# Altium schematic sheet DHT22.SchDoc(DHT22)
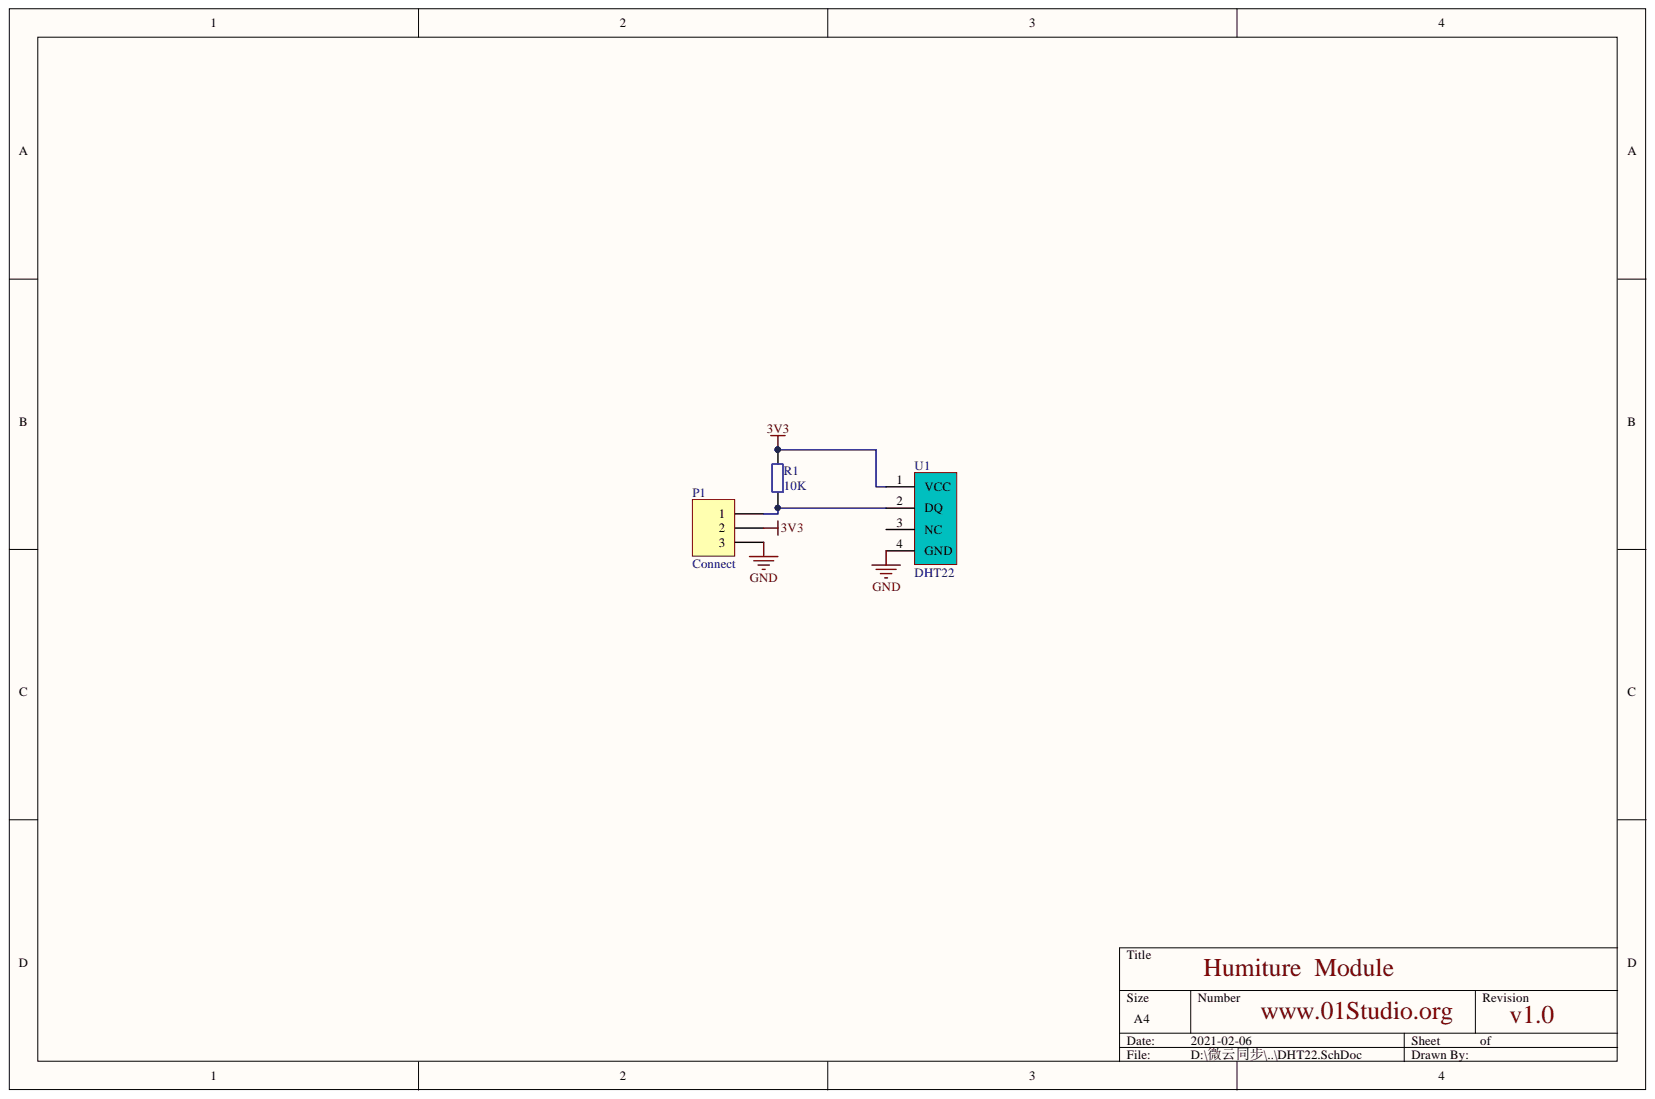
[diagram p0: DHT22.SchDoc]

## Parts
P1  Connect  [XH2.54-3P/卧贴]
R1  value 10K  [R0603]
U1  DHT22  [DHT22]

## Nets
3V3: P1.2 R1.2 U1.1(VCC)
GND: P1.3 U1.4(GND)
NetP1_1: P1.1 R1.1 U1.2(DQ)

Not connected: U1 3(NC)

## Library data of the parts
P1 = Header 3: Header, 3-Pin; Signal Integrity: Connector; Library Name: Miscellaneous Connectors.IntLib; LatestRevisionNote: Re-released for DXP Platform.; LatestRevisionDate: 17-Jul-2002; Component Kind: Standard; Publisher: Altium Limited
R1 = Res2: Resistor; Simulation: RESISTOR; Signal Integrity: Res; Published: 8-Jun-2000; PackageReference: AXIAL-0.4; Library Name: Miscellaneous Devices.IntLib; LatestRevisionNote: Re-released for DXP Platform.; LatestRevisionDate: 17-Jul-2002; Component Kind: Standard; Publisher: Altium Limited
U1 = DHT11: Library Name: WeBee.SCHLIB; Component Kind: Standard
